# Altium schematic sheet COMIF.SchDoc(COMIF)
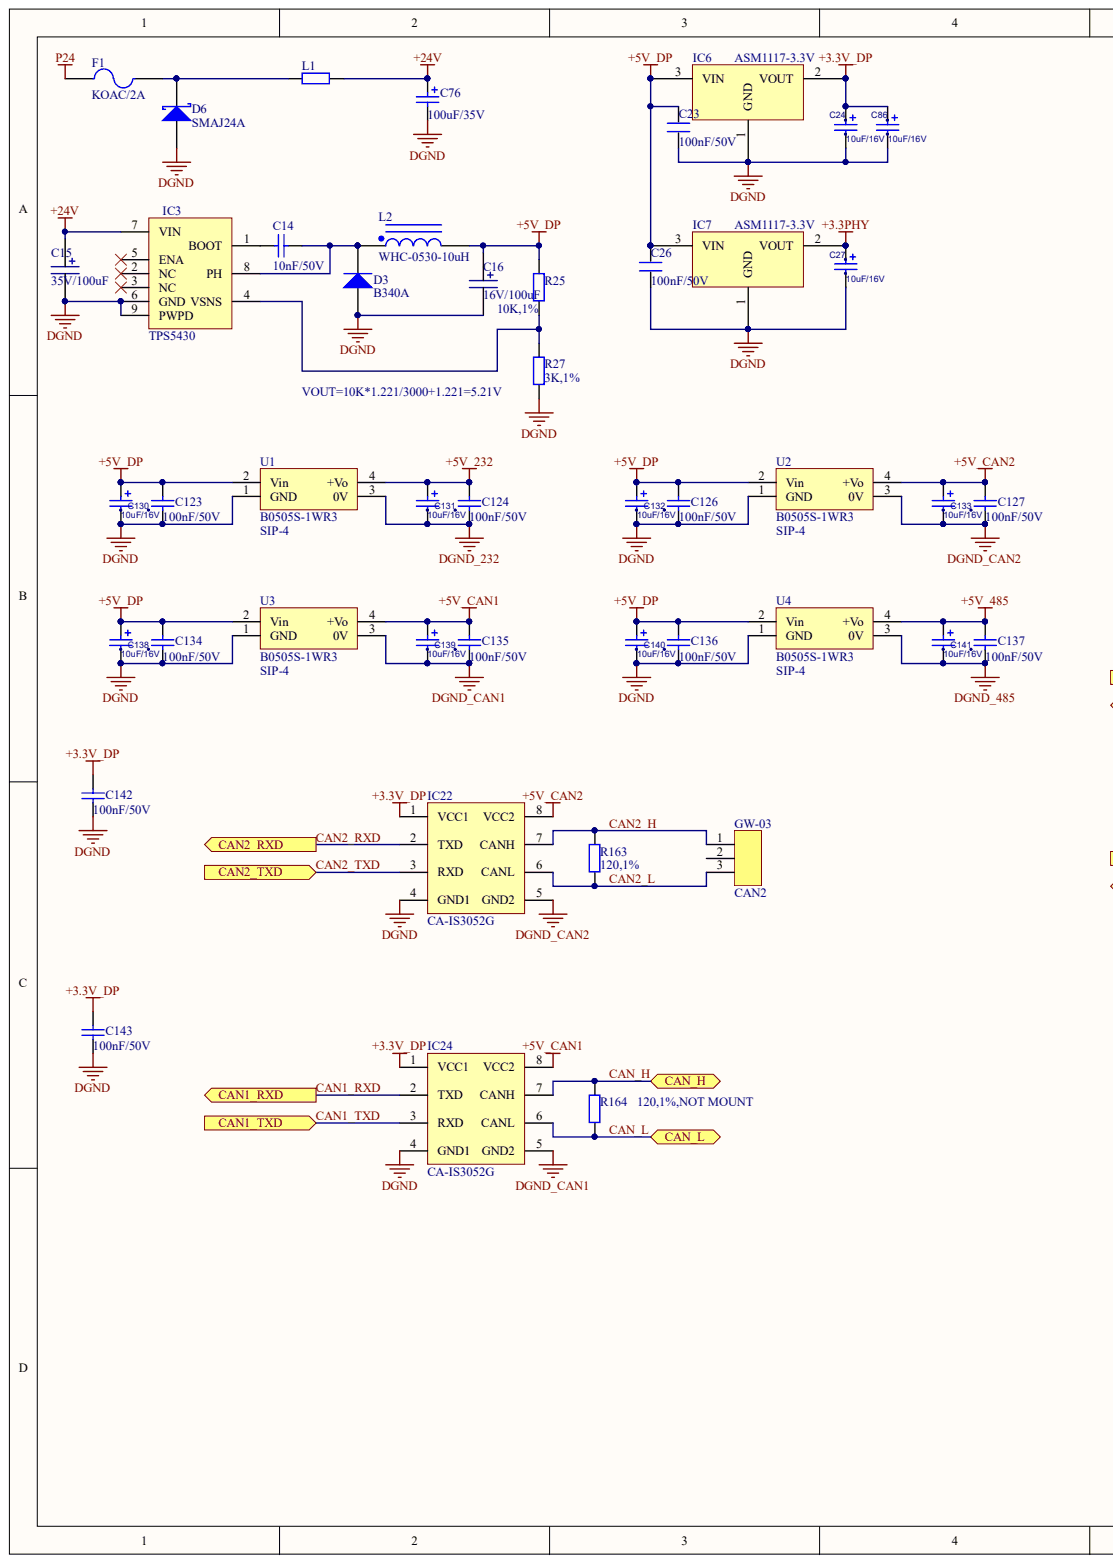
[diagram p0: COMIF.SchDoc, left]
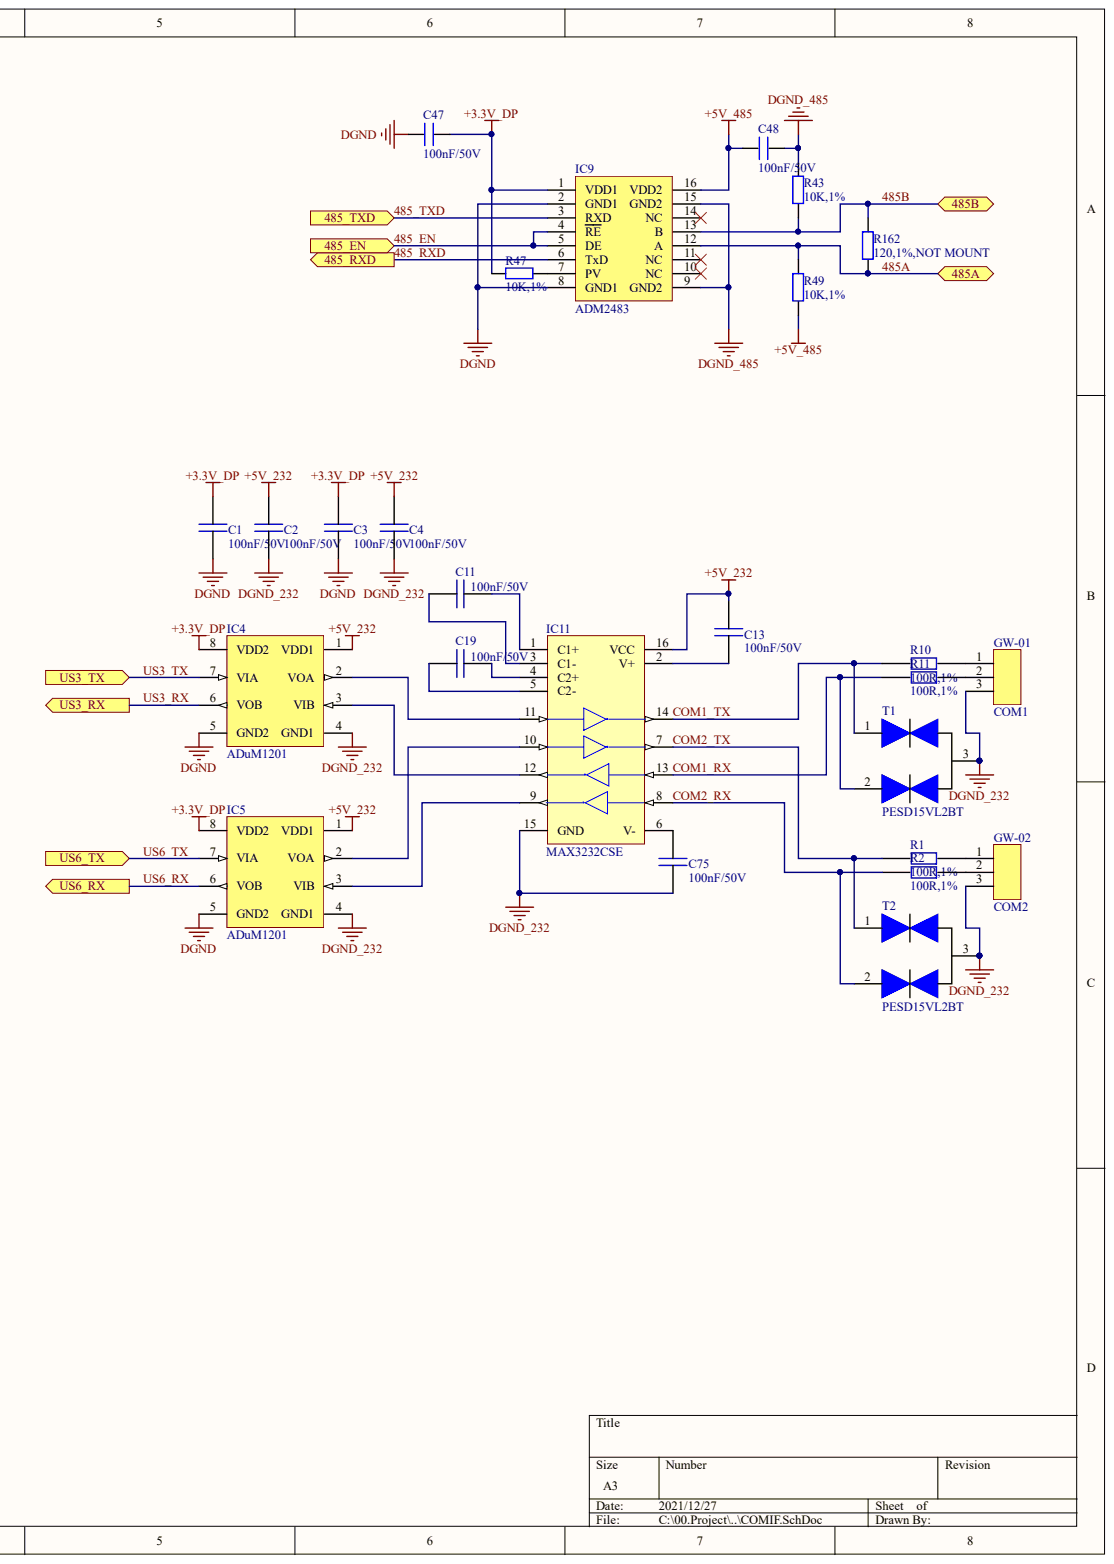
[diagram p1: COMIF.SchDoc, right]

## Parts
C1  100nF/50V  [C0603]
C2  100nF/50V  [C0603]
C3  100nF/50V  [C0603]
C4  100nF/50V  [C0603]
C11  100nF/50V  [C0603]
C13  100nF/50V  [C0603]
C14  10nF/50V  [R0603]
C15  35V/100uF  [ECAP_D6.3]
C16  16V/100uF  [ECAP_D6.3]
C19  100nF/50V  [C0603]
C23  100nF/50V  [C0603]
C24  10uF/16V  [TCA3216]
C26  100nF/50V  [C0603]
C27  10uF/16V  [TCA3216]
C47  100nF/50V  [C0603]
C48  100nF/50V  [C0603]
C75  100nF/50V  [C0603]
C76  100uF/35V  [ECAP_D6.3]
C86  10uF/16V  [TCA3216]
C123  100nF/50V  [C0603]
C124  100nF/50V  [C0603]
C126  100nF/50V  [C0603]
C127  100nF/50V  [C0603]
C130  10uF/16V  [TCA3216]
C131  10uF/16V  [TCA3216]
C132  10uF/16V  [TCA3216]
C133  10uF/16V  [TCA3216]
C134  100nF/50V  [C0603]
C135  100nF/50V  [C0603]
C136  100nF/50V  [C0603]
C137  100nF/50V  [C0603]
C138  10uF/16V  [TCA3216]
C139  10uF/16V  [TCA3216]
C140  10uF/16V  [TCA3216]
C141  10uF/16V  [TCA3216]
C142  100nF/50V  [C0603]
C143  100nF/50V  [C0603]
D3  B340A  [DO-214AC]
D6  SMAJ24A  [DO-214AC]
F1  KOAC/2A  [FUSE6025]
GW-01  COM1  [HX25025-3WA]
GW-02  COM2  [HX25025-3WA]
GW-03  CAN2  [HX25025-3WA]
IC3  TPS5430  [TPS5430]
IC4  ADuM1201  [SOP8_N]
IC5  ADuM1201  [SOP8_N]
IC6  ASM1117-3.3V  [SOT89]
IC7  ASM1117-3.3V  [SOT89]
IC9  ADM2483  [ADM2483]
IC11  MAX3232CSE  [NSO16_N]
IC22  CA-IS3052G  [SOIC8-WB]
IC24  CA-IS3052G  [SOIC8-WB]
L1  BLM21AG151SN1D  [CAPC2012L]  (value not drawn)
L2  WHC-0530-10uH  [WHC0530]
R1  100R,1%  [R0603]
R2  100R,1%  [R0603]
R10  100R,1%  [R0603]
R11  100R,1%  [R0603]
R25  10K,1%  [R0603]
R27  3K,1%  [R0603]
R43  10K,1%  [R0603]
R47  10K,1%  [R0603]
R49  10K,1%  [R0603]
R162  120,1%,NOT MOUNT  [R0603]
R163  120,1%  [R0603]
R164  120,1%,NOT MOUNT  [R0603]
T1  PESD15VL2BT  [SOT23-3]
T2  PESD15VL2BT  [SOT23-3]
U1  value B0505S-1WR3  [SIP-4]  (hidden comment: U_B0505S-1WR3_SIP-4)
U2  value B0505S-1WR3  [SIP-4]  (hidden comment: U_B0505S-1WR3_SIP-4)
U3  value B0505S-1WR3  [SIP-4]  (hidden comment: U_B0505S-1WR3_SIP-4)
U4  value B0505S-1WR3  [SIP-4]  (hidden comment: U_B0505S-1WR3_SIP-4)

## Ports
485_EN, 485_RXD, 485_TXD, 485A, 485B, CAN1_RXD, CAN1_TXD, CAN2_RXD, CAN2_TXD, CAN_H, CAN_L, US3_RX, US3_TX, US6_RX, US6_TX

## Nets
+3.3PHY: C27.1 IC7.2
+3.3V_DP: C1.2 C3.2 C24.1 C47.1 C86.1 C142.2 C143.2 IC4.8(VDD2) IC5.8(VDD2) IC6.2 IC9.1(VDD1) IC22.1(VCC1) IC24.1(VCC1) R47.1
+5V_232: C2.2 C4.2 C13.2 C124.2 C131.1 IC4.1(VDD1) IC5.1(VDD1) IC11.16(VCC) U1.4(+Vo)
+5V_485: C48.2 C137.2 C141.1 IC9.16(VDD2) R49.1 U4.4(+Vo)
+5V_CAN1: C135.2 C139.1 IC24.8(VCC2) U3.4(+Vo)
+5V_CAN2: C127.2 C133.1 IC22.8(VCC2) U2.4(+Vo)
+5V_DP: C16.1 C23.2 C26.2 C123.2 C126.2 C130.1 C132.1 C134.2 C136.2 C138.1 C140.1 IC6.3 IC7.3 L2.2 R25.2 U1.2(Vin) U2.2(Vin) U3.2(Vin) U4.2(Vin)
+24V: C15.1 C76.1 IC3.7(VIN) L1.2
DGND: C1.1 C3.1 C15.2 C16.2 C23.1 C24.2 C26.1 C27.2 C47.2 C76.2 C86.2 C123.1 C126.1 C130.2 C132.2 C134.1 C136.1 C138.2 C140.2 C142.1 C143.1 D3.1 D6.1 IC3.6(GND) IC3.9(PWPD) IC4.5(GND2) IC5.5(GND2) IC6.1 IC7.1 IC9.2(GND1) IC9.8(GND1) IC22.4(GND1) IC24.4(GND1) R27.1 U1.1(GND) U2.1(GND) U3.1(GND) U4.1(GND)
DGND_232: C2.1 C4.1 C75.1 C124.1 C131.2 GW-01.3 GW-02.3 IC4.4(GND1) IC5.4(GND1) IC11.15(GND) T1.3 T2.3 U1.3(0V)
DGND_485: C48.1 C137.1 C141.2 IC9.9(GND2) IC9.15(GND2) R43.2 U4.3(0V)
DGND_CAN1: C135.1 C139.2 IC24.5(GND2) U3.3(0V)
DGND_CAN2: C127.1 C133.2 IC22.5(GND2) U2.3(0V)
P24: F1.1
485_EN: IC9.4(RE) IC9.5(DE) ; port 485_EN
485_RXD: IC9.6(TxD) ; port 485_RXD
485_TXD: IC9.3(RXD) ; port 485_TXD
485A: IC9.12(A) R49.2 R162.1 ; port 485A
485B: IC9.13(B) R43.1 R162.2 ; port 485B
CAN1_RXD: IC24.2(TXD) ; port CAN1_RXD
CAN1_TXD: IC24.3(RXD) ; port CAN1_TXD
CAN2_H: GW-03.1 IC22.7(CANH) R163.2
CAN2_L: GW-03.3 IC22.6(CANL) R163.1
CAN2_RXD: IC22.2(TXD) ; port CAN2_RXD
CAN2_TXD: IC22.3(RXD) ; port CAN2_TXD
CAN_H: IC24.7(CANH) R164.2 ; port CAN_H
CAN_L: IC24.6(CANL) R164.1 ; port CAN_L
COM1_RX: IC11.13 R11.1 T1.2
COM1_TX: IC11.14 R10.1 T1.1
COM2_RX: IC11.8 R2.1 T2.2
COM2_TX: IC11.7 R1.1 T2.1
US3_RX: IC4.6(VOB) ; port US3_RX
US3_TX: IC4.7(VIA) ; port US3_TX
US6_RX: IC5.6(VOB) ; port US6_RX
US6_TX: IC5.7(VIA) ; port US6_TX
NetC11_1: C11.1 IC11.3(C1-)
NetC11_2: C11.2 IC11.1(C1+)
NetC13_1: C13.1 IC11.2(V+)
NetC14_1: C14.1 D3.2 IC3.8(PH) L2.1
NetC14_2: C14.2 IC3.1(BOOT)
NetC19_1: C19.1 IC11.4(C2+)
NetC19_2: C19.2 IC11.5(C2-)
NetC75_2: C75.2 IC11.6(V-)
NetD6_2: D6.2 F1.2 L1.1
NetGW-01_1: GW-01.1 R10.2
NetGW-01_2: GW-01.2 R11.2
NetGW-02_1: GW-02.1 R1.2
NetGW-02_2: GW-02.2 R2.2
NetIC3_4: IC3.4(VSNS) R25.1 R27.2
NetIC4_2: IC4.2(VOA) IC11.11
NetIC4_3: IC4.3(VIB) IC11.12
NetIC5_2: IC5.2(VOA) IC11.10
NetIC5_3: IC5.3(VIB) IC11.9
NetIC9_7: IC9.7(PV) R47.2

Not connected: GW-03 2; IC3 2(NC) 3(NC) 5(ENA); IC9 10(NC) 11(NC) 14(NC)

## Library data of the parts
C1-C4 C11 C13 C19 C75 = CAP: Component Kind: Standard; Library Name: ZYFShLib.SchLib
C14 C123 C124 C126 C127 C134-C137 C142 C143 = Cap: Capacitor; Value: 100nF; Component Kind: Standard; LatestRevisionDate: 17-Jul-2002; LatestRevisionNote: Re-released for DXP Platform.; Library Name: Miscellaneous Devices.IntLib; PackageReference: RAD-0.3; Published: 8-Jun-2000; Signal Integrity: Cap; Simulation: CAP; Publisher: Altium Limited
C15 C16 = ECAP: Component Kind: Standard; Library Name: ZYFShLib.SchLib
C23 C26 C47 C48 = C: Component Kind: Standard; Library Name: IMX6UL_ALPHA(底板)_V2.0.IntLib
C24 C27 C86 = TCA3216: ROOM: LM3S5P36; 物?: 102030236; : 电容,(贴片),18pF/50V,±5%,0805,COG; Component Kind: Standard; Library Name: mypcb.SCHLIB
C76 = 100uF/50V: Polarized Capacitor (Radial); Component Kind: Standard; LatestRevisionDate: 17-Jul-2002; LatestRevisionNote: Re-released for DXP Platform.; PackageDescription: Polarized Capacitor (Radial); 2 Leads; PackageReference: RB7.6-15; Published: 8-Jun-2000; Signal Integrity: Cap Pol; Simulation: CAP; Value: 100uF/35V; Library Name: mypcb.SCHLIB; Publisher: Altium Limited
C130-C133 C138-C141 = TCA3216: ROOM: LM3S5P36; 物料编码: 102030236; : 电容,(贴片),18pF/50V,±5%,0805,COG; Component Kind: Standard; Library Name: mypcb.SCHLIB
D3 = Diode 1N4001: 1 Amp General Purpose Rectifier; Code_JEDEC: DO-204-AL; Component Kind: Standard; ComponentLink1Description: Manufacturer Link; ComponentLink1URL: http://www.fairchildsemi.com/; ComponentLink2Description: Datasheet; ComponentLink2URL: http://www.fairchildsemi.com/ds/1N%2F1N4001.pdf; DatasheetVersion: 1998; LatestRevisionDate: 17-Jul-2002; LatestRevisionNote: Re-released for DXP Platform.; Library Name: Miscellaneous Devices.IntLib; PackageDescription: Diode, Axial; Body 5.2 x 2.7 mm (LxDia. max), Lead Dia. 0.86 mm (max); PackageReference: DO-41; PackageVersion: Aug-1999; Published: 8-Jun-2000; Signal Integrity: 1N4001; Simulation: 1N4001; Publisher: Altium Limited
D6 = D Schottky: Schottky Diode; Code_JEDEC: DO-214-AA; Component Kind: Standard; LatestRevisionDate: 17-Jul-2002; LatestRevisionNote: Re-released for DXP Platform.; Library Name: Miscellaneous Devices.IntLib; PackageDescription: DO-214-AA/SMB; 2 C-Bend Leads; Body 5.3 x 3.6 mm, inc. leads (LxW); PackageReference: SMB; Published: 8-Jun-2000; Signal Integrity: D Schottky; Simulation: SKYDIODE; Publisher: Altium Limited
F1 = Fuse 2: Fuse; Component Kind: Standard; LatestRevisionDate: 17-Jul-2002; LatestRevisionNote: Re-released for DXP Platform.; Library Name: Miscellaneous Devices.IntLib; PackageDescription: Thru-Hole; 2 Leads; PackageReference: PIN-W2/E2.8; Published: 8-Jun-2000; Simulation: FUSE; Publisher: Altium Limited
GW-01 GW-02 GW-03 = CON2.54-3: Component Kind: Standard; Library Name: STM32.SchLib
IC6 IC7 = ASM1117-3V3: Component Kind: Standard; Library Name: STM32.SchLib
IC11 = MAX3232CSE: 3.0V TO 5.5V, Low-Power, up to 1Mbps, True RS-232 Transceiver Using Four 0.1礔 External Capacitor; Code_IPC: SOIC127P600-16; Code_JEDEC: MS-012-AC; Component Kind: Standard; ComponentLink1Description: Manufacturer Link; ComponentLink1URL: http://www.maxim-ic.com/; ComponentLink2Description: Datasheet; ComponentLink2URL: http://pdfserv.maxim-ic.com/en/ds/MAX3222-MAX3241.pdf; DatasheetVersion: Mar-1999; LatestRevisionDate: 13-Apr-2006; LatestRevisionNote: IPC-7351 Footprint Added.; Library Name: Maxim Communication Transceiver.IntLib; PackageDescription: 16-Pin Small Outline Integrated Circuit 1.27 mm Pitch; PackageReference: NSO16; PCB3D: NSO16; Published: 16-Jan-2001; Publisher: Altium Limited
L1 R25 R27 R43 R47 R49 R162-R164 = Res2: Resistor; Value: 1K; Component Kind: Standard; LatestRevisionDate: 17-Jul-2002; LatestRevisionNote: Re-released for DXP Platform.; Library Name: Miscellaneous Devices.IntLib; PackageReference: AXIAL-0.4; Published: 8-Jun-2000; Signal Integrity: Res; Simulation: RESISTOR; Publisher: Altium Limited
L2 = Inductor Iron Dot: Magnetic-Core Inductor with Winding Polarity Marking; Value: 22uH; Component Kind: Standard; LatestRevisionDate: 17-Jul-2002; LatestRevisionNote: Re-released for DXP Platform.; Library Name: Miscellaneous Devices.IntLib; PackageReference: DIODE_SMC; Published: 24-Mar-1999; Signal Integrity: Inductor Iron Dot; Publisher: Altium Limited
R1 R2 R10 R11 = RES: Component Kind: Standard; Library Name: ZYFShLib.SchLib
U1-U4 = U_B0505S-1WR3_SIP-4: 隔离电源模块 Vin 5.5V    Vo 5V    1W    200mA; Manufacturer: 金升阳; Component Kind: Standard; Library Name: Common_YSD2.SchLib; PCB Footprint: SIP-4
IC3 = TPS5430: Component Kind: Standard; Library Name: STM32.SchLib
IC4 IC5 = ADuM1201: Component Kind: Standard; Library Name: STM32.SchLib
IC9 = ADM2483: Component Kind: Standard; Library Name: STM3.SCHLIB
IC22 IC24 = CA-IS3052G: Component Kind: Standard; Library Name: Common_YSD.SchLib
T1 T2 = PESD15VL2BT: Component Kind: Standard; Library Name: STM32.SchLib
